# Altium schematic sheet 50SW_SCH.SchDoc
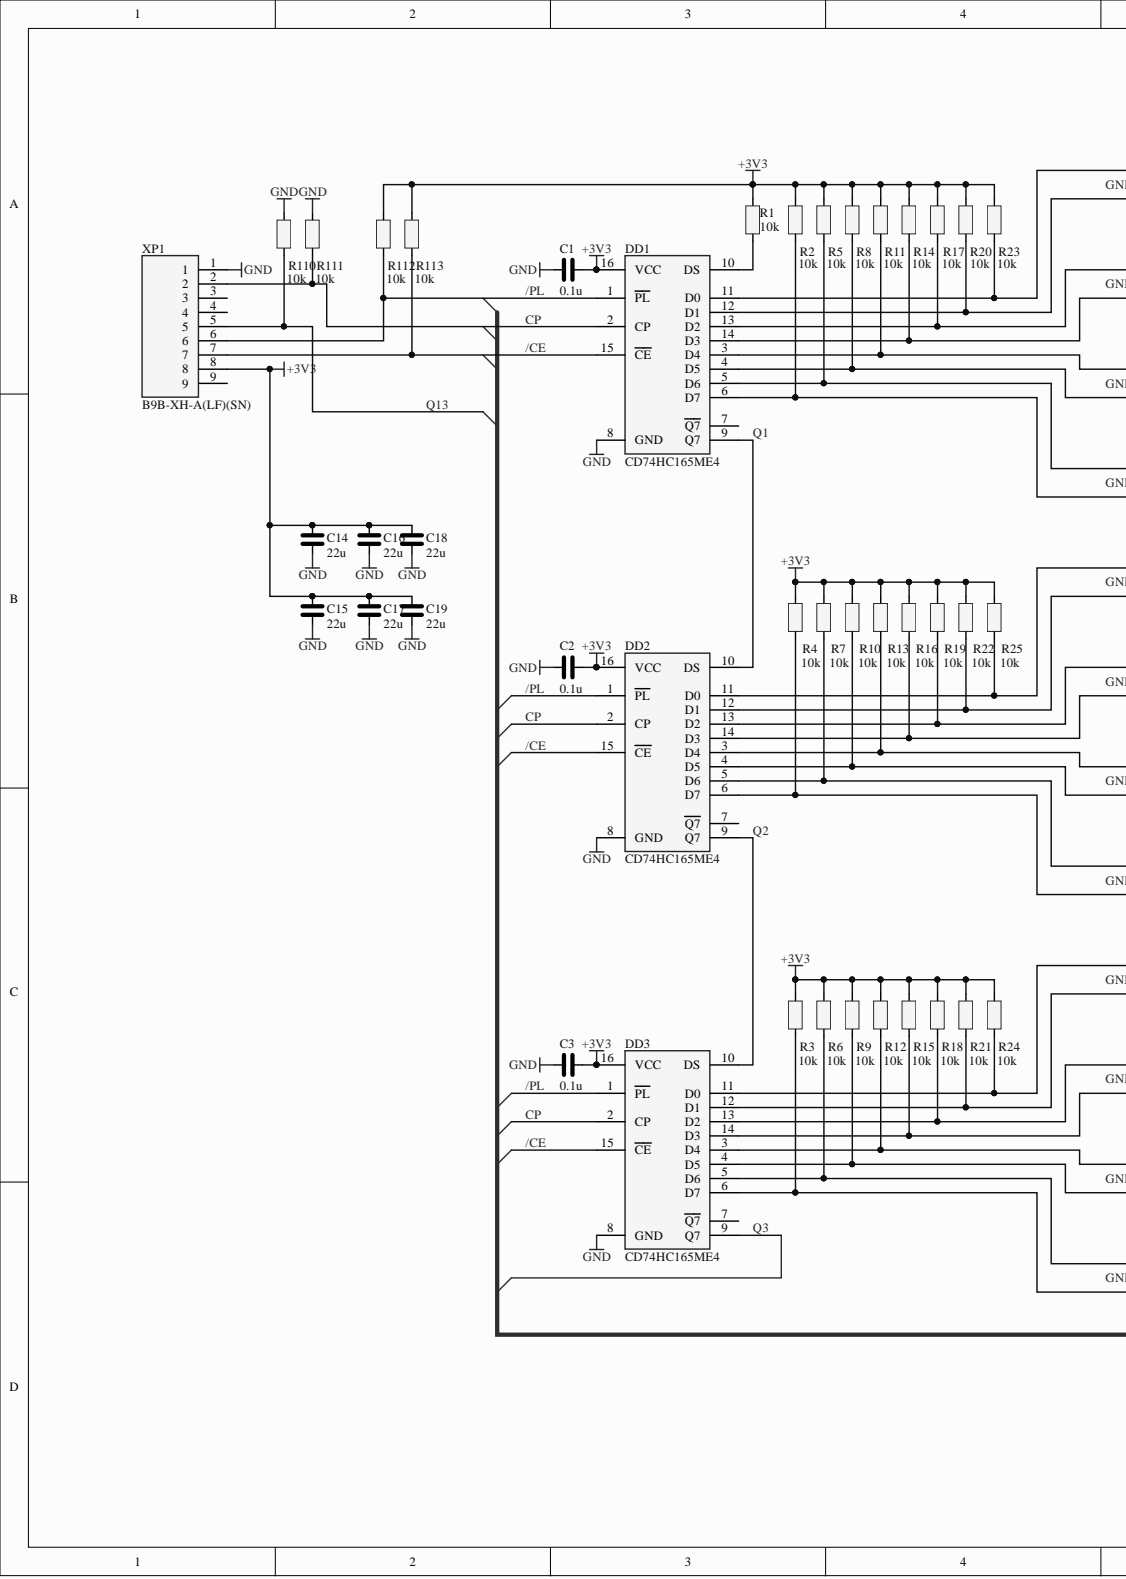
[diagram p0: 50SW_SCH.SchDoc, left]
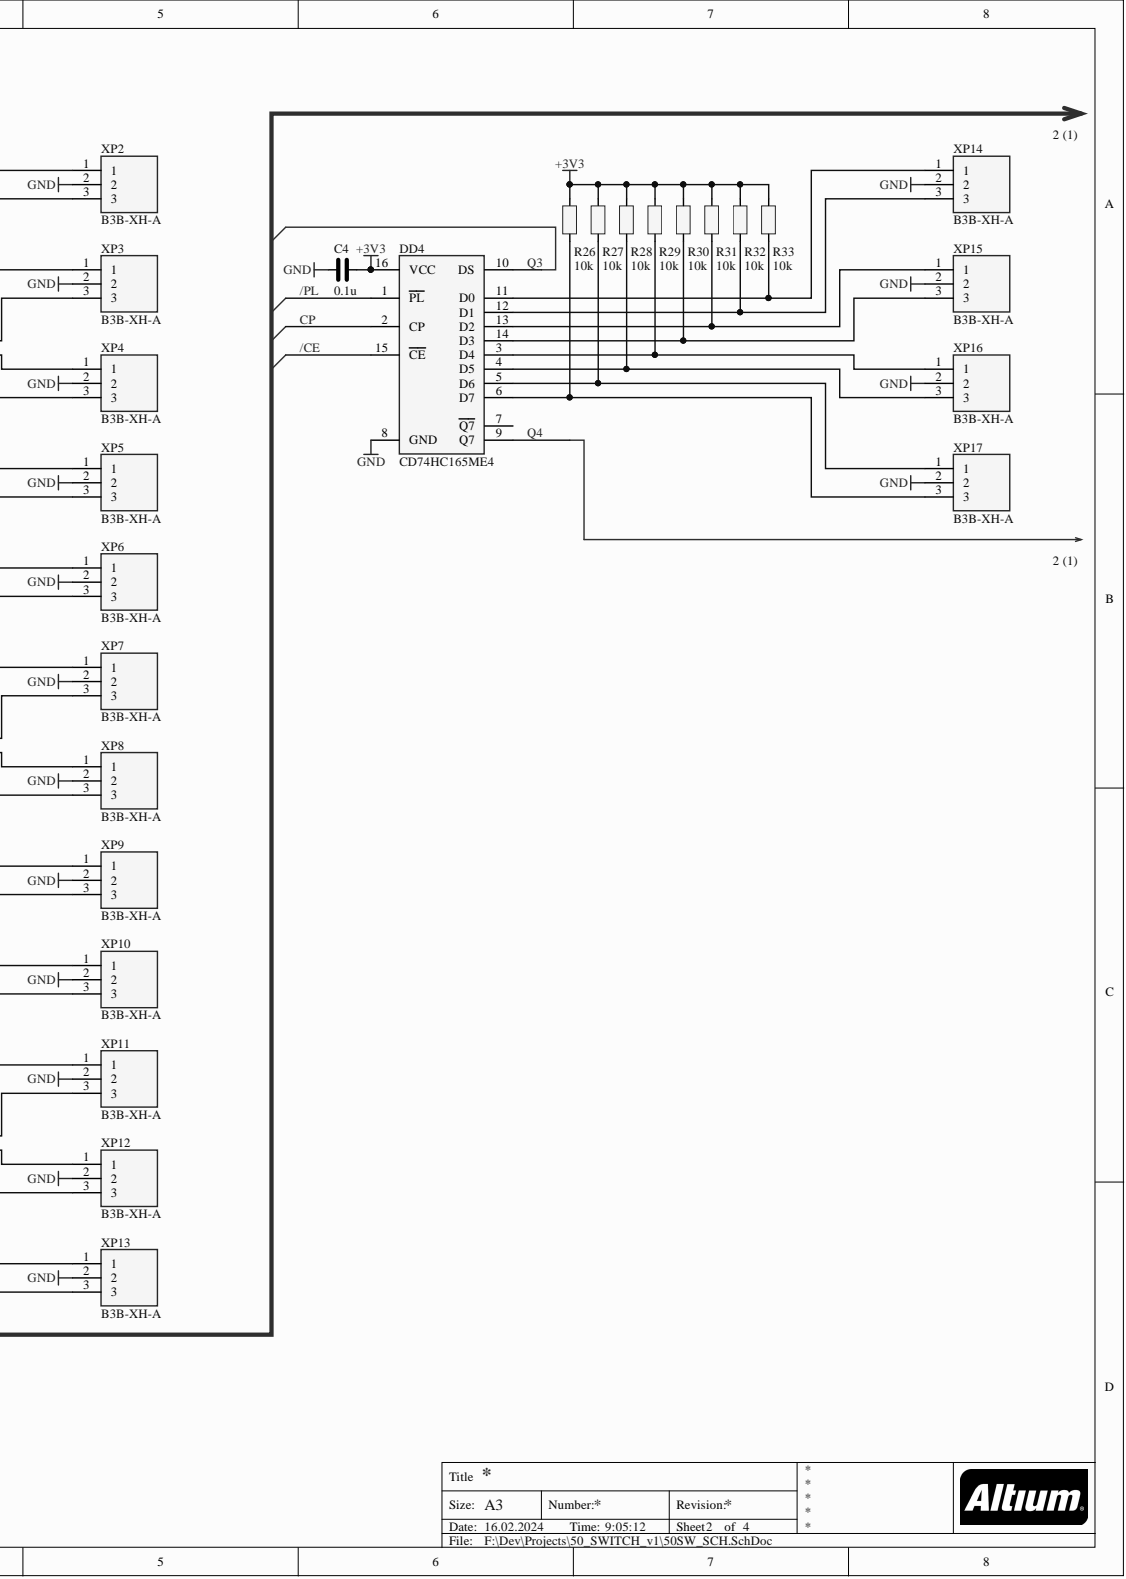
[diagram p1: 50SW_SCH.SchDoc, right]

## Parts
C1  0.1u  [C0603]
C2  0.1u  [C0603]
C3  0.1u  [C0603]
C4  0.1u  [C0603]
C14  22u  [C1206]
C15  22u  [C1206]
C16  22u  [C1206]
C17  22u  [C1206]
C18  22u  [C1206]
C19  22u  [C1206]
DD1  CD74HC165ME4  [SOIC127P600X175-16N]
DD2  CD74HC165ME4  [SOIC127P600X175-16N]
DD3  CD74HC165ME4  [SOIC127P600X175-16N]
DD4  CD74HC165ME4  [SOIC127P600X175-16N]
R1  10k  [R0603]
R2  10k  [R0603]
R3  10k  [R0603]
R4  10k  [R0603]
R5  10k  [R0603]
R6  10k  [R0603]
R7  10k  [R0603]
R8  10k  [R0603]
R9  10k  [R0603]
R10  10k  [R0603]
R11  10k  [R0603]
R12  10k  [R0603]
R13  10k  [R0603]
R14  10k  [R0603]
R15  10k  [R0603]
R16  10k  [R0603]
R17  10k  [R0603]
R18  10k  [R0603]
R19  10k  [R0603]
R20  10k  [R0603]
R21  10k  [R0603]
R22  10k  [R0603]
R23  10k  [R0603]
R24  10k  [R0603]
R25  10k  [R0603]
R26  10k  [R0603]
R27  10k  [R0603]
R28  10k  [R0603]
R29  10k  [R0603]
R30  10k  [R0603]
R31  10k  [R0603]
R32  10k  [R0603]
R33  10k  [R0603]
R110  10k  [R0603]
R111  10k  [R0603]
R112  10k  [R0603]
R113  10k  [R0603]
XP1  B9B-XH-A(LF)(SN)  [SHDR9W64P0X250_1X9_2490X575X700P]
XP2  B3B-XH-A  [SHDR3W64P0X250_1X3_990X575X700P]
XP3  B3B-XH-A  [SHDR3W64P0X250_1X3_990X575X700P]
XP4  B3B-XH-A  [SHDR3W64P0X250_1X3_990X575X700P]
XP5  B3B-XH-A  [SHDR3W64P0X250_1X3_990X575X700P]
XP6  B3B-XH-A  [SHDR3W64P0X250_1X3_990X575X700P]
XP7  B3B-XH-A  [SHDR3W64P0X250_1X3_990X575X700P]
XP8  B3B-XH-A  [SHDR3W64P0X250_1X3_990X575X700P]
XP9  B3B-XH-A  [SHDR3W64P0X250_1X3_990X575X700P]
XP10  B3B-XH-A  [SHDR3W64P0X250_1X3_990X575X700P]
XP11  B3B-XH-A  [SHDR3W64P0X250_1X3_990X575X700P]
XP12  B3B-XH-A  [SHDR3W64P0X250_1X3_990X575X700P]
XP13  B3B-XH-A  [SHDR3W64P0X250_1X3_990X575X700P]
XP14  B3B-XH-A  [SHDR3W64P0X250_1X3_990X575X700P]
XP15  B3B-XH-A  [SHDR3W64P0X250_1X3_990X575X700P]
XP16  B3B-XH-A  [SHDR3W64P0X250_1X3_990X575X700P]
XP17  B3B-XH-A  [SHDR3W64P0X250_1X3_990X575X700P]

## Nets
+3V3: C1.1 C2.1 C3.1 C4.1 C14.2 C15.2 C16.2 C17.2 C18.2 C19.2 DD1.16(VCC) DD2.16(VCC) DD3.16(VCC) DD4.16(VCC) R1.1 R2.1 R3.1 R4.1 R5.1 R6.1 R7.1 R8.1 R9.1 R10.1 R11.1 R12.1 R13.1 R14.1 R15.1 R16.1 R17.1 R18.1 R19.1 R20.1 R21.1 R22.1 R23.1 R24.1 R25.1 R26.1 R27.1 R28.1 R29.1 R30.1 R31.1 R32.1 R33.1 R112.1 R113.1 XP1.8
GND: C1.2 C2.2 C3.2 C4.2 C14.1 C15.1 C16.1 C17.1 C18.1 C19.1 DD1.8(GND) DD2.8(GND) DD3.8(GND) DD4.8(GND) R110.1 R111.1 XP1.1 XP2.2 XP3.2 XP4.2 XP5.2 XP6.2 XP7.2 XP8.2 XP9.2 XP10.2 XP11.2 XP12.2 XP13.2 XP14.2 XP15.2 XP16.2 XP17.2
/CE: DD1.15(CE) DD2.15(CE) DD3.15(CE) DD4.15(CE) R113.2 XP1.7
/PL: DD1.1(PL) DD2.1(PL) DD3.1(PL) DD4.1(PL) R112.2 XP1.6
CP: DD1.2(CP) DD2.2(CP) DD3.2(CP) DD4.2(CP) R111.2 XP1.2
Q1: DD1.9(Q7) DD2.10(DS)
Q2: DD2.9(Q7) DD3.10(DS)
Q3: DD3.9(Q7) DD4.10(DS)
Q4: DD4.9(Q7)
Q13: R110.2 XP1.5
NetDD1_3: DD1.3(D4) R11.2 XP4.1
NetDD1_4: DD1.4(D5) R8.2 XP4.3
NetDD1_5: DD1.5(D6) R5.2 XP5.1
NetDD1_6: DD1.6(D7) R2.2 XP5.3
NetDD1_10: DD1.10(DS) R1.2
NetDD1_11: DD1.11(D0) R23.2 XP2.1
NetDD1_12: DD1.12(D1) R20.2 XP2.3
NetDD1_13: DD1.13(D2) R17.2 XP3.1
NetDD1_14: DD1.14(D3) R14.2 XP3.3
NetDD2_3: DD2.3(D4) R13.2 XP8.1
NetDD2_4: DD2.4(D5) R10.2 XP8.3
NetDD2_5: DD2.5(D6) R7.2 XP9.1
NetDD2_6: DD2.6(D7) R4.2 XP9.3
NetDD2_11: DD2.11(D0) R25.2 XP6.1
NetDD2_12: DD2.12(D1) R22.2 XP6.3
NetDD2_13: DD2.13(D2) R19.2 XP7.1
NetDD2_14: DD2.14(D3) R16.2 XP7.3
NetDD3_3: DD3.3(D4) R12.2 XP12.1
NetDD3_4: DD3.4(D5) R9.2 XP12.3
NetDD3_5: DD3.5(D6) R6.2 XP13.1
NetDD3_6: DD3.6(D7) R3.2 XP13.3
NetDD3_11: DD3.11(D0) R24.2 XP10.1
NetDD3_12: DD3.12(D1) R21.2 XP10.3
NetDD3_13: DD3.13(D2) R18.2 XP11.1
NetDD3_14: DD3.14(D3) R15.2 XP11.3
NetDD4_3: DD4.3(D4) R29.2 XP16.1
NetDD4_4: DD4.4(D5) R28.2 XP16.3
NetDD4_5: DD4.5(D6) R27.2 XP17.1
NetDD4_6: DD4.6(D7) R26.2 XP17.3
NetDD4_11: DD4.11(D0) R33.2 XP14.1
NetDD4_12: DD4.12(D1) R32.2 XP14.3
NetDD4_13: DD4.13(D2) R31.2 XP15.1
NetDD4_14: DD4.14(D3) R30.2 XP15.3

Not connected: DD1 7(Q7); DD2 7(Q7); DD3 7(Q7); DD4 7(Q7); XP1 3 4 9

## Library data of the parts
C1-C4 = C0603: BOMVal: 0603-X7R-16 В-0.1 мкФ ±10% керамический; Dielectric: X7R; Scale: u; ScaleR: мк; SizeImp: 0603; Tolerance: 10; TypeR: керамический; Value: 0.1; Voltage: 16; Component Kind: Standard; Library Name: passive.SchLib
C14-C19 = C1206: Manufacturer: Murata; PartNumber: GRM31CR71A226KE15L; BOMVal: 1206-X7R-10 В-22 мкФ±10% керамический; Dielectric: X7R; NameR: Конденсатор; Scale: u; ScaleR: мкФ; SizeImp: 1206; Tolerance: 10; TypeR: керамический; Value: 22; Component Kind: Standard; Library Name: passive.SchLib; Voltage: 10
DD1-DD4 = CD74HC165ME4: Integrated Circuit; Manufacturer_Name: Texas Instruments; BOMVal: Микросхема  CD74HC165ME4; NameR: Микросхема; Component Kind: Standard; Datasheet Link: https://www.ti.com/lit/gpn/cd74hc165; Height: 1.75mm; Library Name: SamacSys.SchLib; PartNumber: CD74HC165ME4
R1-R33 R110-R113 = R0603: BOMVal: 0603-0.1 Вт-10 кОмОм±5%; Power: 0.1; Scale: k; ScaleR: кОм; SizeImp: 0603; Tolerance: 5; Value: 10; Component Kind: Standard; Library Name: passive.SchLib
XP1 = B9B-XH-A_LF__SN_: Connector; Manufacturer_Name: JST (JAPAN SOLDERLESS TERMINALS); BOMVal: Вилка на плату B9B-XH-A(LF)(SN) JST (JAPAN SOLDERLESS TERMINALS); NameR: Вилка; TypeR: на плату; Component Kind: Standard; Datasheet Link: http://www.jst-mfg.com/product/pdf/eng/eXH.pdf; Height: 7mm; Library Name: MyLib3.SchLib; PartNumber: B9B-XH-A(LF)(SN)
XP2-XP17 = B3B-XH-A: Connector; Manufacturer_Name: JST (JAPAN SOLDERLESS TERMINALS); BOMVal: Вилка на плату B3B-XH-A JST (JAPAN SOLDERLESS TERMINALS); NameR: Вилка; TypeR: на плату; Component Kind: Standard; Datasheet Link: http://www.jst-mfg.com/product/pdf/eng/eXH.pdf; Height: 7mm; Library Name: SamacSys_By.SchLib; PartNumber: B3B-XH-A
